# Altium schematic sheet Power.SchDoc
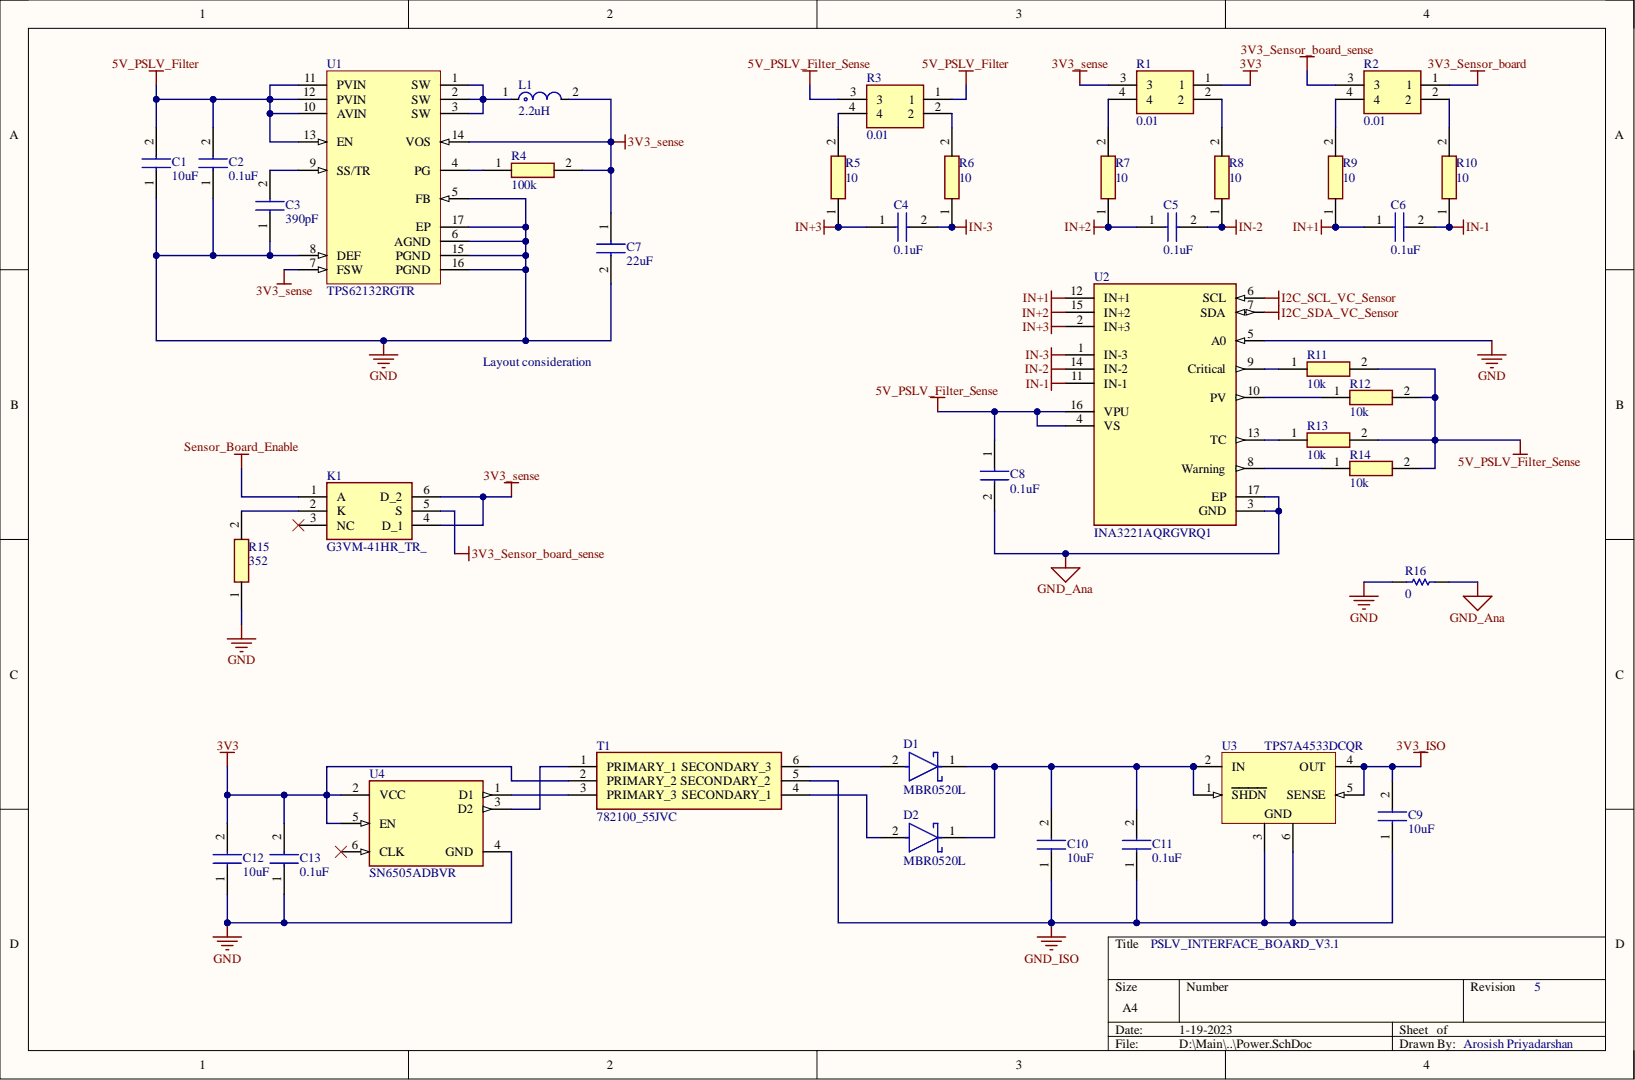
[diagram p0: Power.SchDoc]

## Parts
C1  10uF  [C1206]
C2  0.1uF  [C1206_FT]
C3  390pF  [C1206]
C4  0.1uF  [C1206_FT]
C5  0.1uF  [C1206_FT]
C6  0.1uF  [C1206_FT]
C7  22uF  [C1206]
C8  0.1uF  [C1206_FT]
C9  10uF  [C1206]
C10  10uF  [C1206]
C11  0.1uF  [C1206_FT]
C12  10uF  [C1206]
C13  0.1uF  [C1206_FT]
D1  MBR0520L  [FP-MA02A-MFG]
D2  MBR0520L  [FP-MA02A-MFG]
K1  G3VM-41HR_TR_  [G3VM41HRTR]
L1  2.2uH  [FP-XGL4030-MFG]
R1  0.01  [LVK12R010CER]
R2  0.01  [LVK12R010CER]
R3  0.01  [LVK12R010CER]
R4  100k  [RESC3216X70N]
R5  10  [RESC3116X65N]
R6  10  [RESC3116X65N]
R7  10  [RESC3116X65N]
R8  10  [RESC3116X65N]
R9  10  [RESC3116X65N]
R10  10  [RESC3116X65N]
R11  10k  [RESC3216X70N]
R12  10k  [RESC3216X70N]
R13  10k  [RESC3216X70N]
R14  10k  [RESC3216X70N]
R15  352  [RESC3216X70N]
R16  0  [RESC3216X70X50LL05T20]
T1  782100_55JVC  [78210055JVC]
U1  TPS62132RGTR  [RGT0016C_V]
U2  INA3221AQRGVRQ1  [FP-RGV0016A-IPC_B]
U3  TPS7A4533DCQR  [DCQ0006A_M]
U4  SN6505ADBVR  [FP-DBV0006A-IPC_A]

## Nets
3V3: C12.2 C13.2 R1.1 T1.2(PRIMARY_2) U4.2(VCC) U4.5(EN)
3V3_ISO: C9.2 U3.4(OUT) U3.5(SENSE)
3V3_sense: C7.1 K1.4(D_1) K1.6(D_2) L1.2 R1.3 R4.2 U1.7(FSW) U1.14(VOS)
3V3_Sensor_board: R2.1
3V3_Sensor_board_sense: K1.5(S) R2.3
5V_PSLV_Filter: C1.2 C2.2 R3.1 U1.10(AVIN) U1.11(PVIN) U1.12(PVIN) U1.13(EN)
5V_PSLV_Filter_Sense: C8.1 R3.3 R11.2 R12.2 R13.2 R14.2 U2.4(VS) U2.16(VPU)
GND: C1.1 C2.1 C3.1 C7.2 C12.1 C13.1 R15.1 R16.1 U1.5(FB) U1.6(AGND) U1.8(DEF) U1.15(PGND) U1.16(PGND) U1.17(EP) U2.5(A0) U4.4(GND)
GND_Ana: C8.2 R16.2 U2.3(GND) U2.17(EP)
GND_ISO: C9.1 C10.1 C11.1 T1.5(SECONDARY_2) U3.3 U3.6
I2C_SCL_VC_Sensor: U2.6(SCL)
I2C_SDA_VC_Sensor: U2.7(SDA)
IN+1: C6.1 R9.1 U2.12(IN+1)
IN+2: C5.1 R7.1 U2.15(IN+2)
IN+3: C4.1 R5.1 U2.2(IN+3)
IN-1: C6.2 R10.1 U2.11(IN-1)
IN-2: C5.2 R8.1 U2.14(IN-2)
IN-3: C4.2 R6.1 U2.1(IN-3)
Sensor_Board_Enable: K1.1(A)
NetC3_2: C3.2 U1.9(SS/TR)
NetC10_2: C10.2 C11.2 D1.1 D2.1 U3.1(SHDN) U3.2(IN)
NetD1_2: D1.2 T1.6(SECONDARY_3)
NetD2_2: D2.2 T1.4(SECONDARY_1)
NetK1_2: K1.2(K) R15.2
NetL1_1: L1.1 U1.1(SW) U1.2(SW) U1.3(SW)
NetR1_2: R1.2 R8.2
NetR1_4: R1.4 R7.2
NetR2_2: R2.2 R10.2
NetR2_4: R2.4 R9.2
NetR3_2: R3.2 R6.2
NetR3_4: R3.4 R5.2
NetR4_1: R4.1 U1.4(PG)
NetR11_1: R11.1 U2.9(Critical)
NetR12_1: R12.1 U2.10(PV)
NetR13_1: R13.1 U2.13(TC)
NetR14_1: R14.1 U2.8(Warning)
NetT1_1: T1.1(PRIMARY_1) U4.3(D2)
NetT1_3: T1.3(PRIMARY_3) U4.1(D1)

Not connected: K1 3(NC); U4 6(CLK)

## Library data of the parts
C1 C9 C10 C12 = C1206C106Z4VACTU: Capacitor; Manufacturer_Name: KEMET; Arrow Part Number: C1206C106Z4VACTU; Arrow Price/Stock: https://www.arrow.com/en/products/c1206c106z4vactu/kemet-corporation?region=nac; Component Kind: Standard; Datasheet Link: https://content.kemet.com/datasheets/KEM_C1005_Y5V_SMD.pdf; Library Name: Schlib1.SchLib; Manufacturer_Part_Number: C1206C106Z4VACTU; Mouser Part Number: 80-C1206C106Z4VACTU; Mouser Price/Stock: https://www.mouser.co.uk/ProductDetail/KEMET/C1206C106Z4VACTU?qs=dm5ynNmggfJrhnd05a604w%3D%3D
C2 C4-C6 C8 C11 C13 = C1206X104K5RAC3316: Capacitor; Manufacturer_Name: KEMET; Arrow Part Number: C1206X104K5RAC3316; Arrow Price/Stock: https://www.arrow.com/en/products/c1206x104k5rac3316/kemet-corporation; Component Kind: Standard; Datasheet Link: https://content.kemet.com/datasheets/KEM_X7R_FT_VW_AUDI.pdf; Library Name: Schlib1.SchLib; Manufacturer_Part_Number: C1206X104K5RAC3316; Mouser Part Number: 80-C1206X104K5RC3316; Mouser Price/Stock: https://www.mouser.co.uk/ProductDetail/KEMET/C1206X104K5RAC3316?qs=PzGy0jfpSMtwBv5U2rNx3w%3D%3D
C3 = C1206C391JCGACTU: Capacitor; Manufacturer_Name: KEMET; Component Kind: Standard; Datasheet Link: https://content.kemet.com/datasheets/KEM_C1009_C0G_HV_SMD.pdf; Library Name: Schlib1.SchLib; Manufacturer_Part_Number: C1206C391JCGACTU; Mouser Part Number: 80-C1206C391JCG; Mouser Price/Stock: https://www.mouser.co.uk/ProductDetail/KEMET/C1206C391JCGACTU?qs=Ex32QJu5HxMzYMtFZMlJig%3D%3D
C7 = C1206C226K8RAC7210: Capacitor; Manufacturer_Name: KEMET; Component Kind: Standard; Datasheet Link: https://content.kemet.com/datasheets/KEM_C1002_X7R_SMD.pdf; Library Name: Schlib1.SchLib; Manufacturer_Part_Number: C1206C226K8RAC7210; Mouser Part Number: 80-C1206C226K8R7210; Mouser Price/Stock: https://www.mouser.co.uk/ProductDetail/KEMET/C1206C226K8RAC7210?qs=rrS6PyfT74d338OvQfUspw%3D%3D
D1 D2 = CMP-2000-04926-2: DIODE SCHOTTKY 20V 500MA SOD123; Capacitance: 4pF; Current Rating: 500A; Element Configuration: Single; Forward Voltage: 385mV; Max Forward Surge Current (Ifsm): 5.5A; Max Reverse Leakage Current: 250uA; Polarity: Standard; Power Dissipation: 400mW; Average Rectified Current: 500mA; Component Kind: Standard; Forward Current: 500mA; Height: 1.03mm; Lead Free: Lead Free; Length: 2.7mm; Library Name: Altium Content Vault; Max Operating Temperature: 125°C; Max Repetitive Reverse Voltage (Vrrm): 20V; Max Reverse Voltage (DC): 20V; Max Surge Current: 5.5A; Min Operating Temperature: -65°C; Mount: Surface Mount; Number of Pins: 2; Output Current: 500mA; Package Quantity: 3000; Packaging: Tape and Reel; Peak Non-Repetitive Surge Current: 5.5A; Peak Reverse Current: 250uA; Radiation Hardening: No; REACH SVHC: No SVHC; Voltage Rating (DC): 20V; Weight: 0.03g; Width: 1.6mm
K1 = G3VM-41HR_TR_: Relay or Contactor; Manufacturer_Name: Omron Electronics; Manufacturer_Part_Number: G3VM-41HR(TR); Arrow Part Number: G3VM-41HR(TR); Arrow Price/Stock: http://www.arrow.com/en/products/g3vm-41hr-tr/omron; Component Kind: Standard; Datasheet Link: http://www.mouser.com/datasheet/2/307/en_g3vm_41hr-10625.pdf; Height: 2.1mm; Mouser Part Number: 653-G3VM-41HRTR; Mouser Price/Stock: https://www.mouser.co.uk/ProductDetail/Omron-Electronics/G3VM-41HRTR?qs=FfQ8ThoHJhH033qdX8DYnA%3D%3D
L1 = CMP-11268-000073-1: Inductor Power Shielded Wirewound 2.2uH 20% 1MHz Composite 8.7A 15mOhm DCR Automotive T/R; Component Kind: Standard; Library Name: Altium Content Vault
R1-R3 = LVK12R010CER: Resistor; Manufacturer_Name: Ohmite; Component Kind: Standard; Datasheet Link: https://datasheet.datasheetarchive.com/originals/distributors/Datasheets_SAMA/2077b5e0bf5fd022871e7f732bf17f75.pdf; Height: 0.65mm; Library Name: Schlib1.SchLib; Manufacturer_Part_Number: LVK12R010CER; Mouser Part Number: 588-LVK12R010CER; Mouser Price/Stock: https://www.mouser.co.uk/ProductDetail/Ohmite/LVK12R010CER?qs=Cb2nCFKsA8qfJ9bVKb1vFw%3D%3D
R4 = RN73H2BTTD1003B10: Resistor; Manufacturer_Name: KOA Speer; Component Kind: Standard; Datasheet Link: https://www.mouser.com/datasheet/2/219/RN73H-1843478.pdf; Height: 0.7mm; Library Name: Schlib1.SchLib; Manufacturer_Part_Number: RN73H2BTTD1003B10; Mouser Part Number: 660-RN73H2BTD1003B10; Mouser Price/Stock: https://www.mouser.co.uk/ProductDetail/KOA-Speer/RN73H2BTTD1003B10?qs=LcEtihlZWqYVlem5AUAudQ%3D%3D
R5-R10 = RT1206BRD0710RL: Resistor; Manufacturer_Name: KEMET; Arrow Part Number: RT1206BRD0710RL; Arrow Price/Stock: https://www.arrow.com/en/products/rt1206brd0710rl/yageo; Component Kind: Standard; Datasheet Link: https://www.arrow.com/en/products/rt1206brd0710rl/yageo; Height: 0.65mm; Library Name: Schlib1.SchLib; Manufacturer_Part_Number: RT1206BRD0710RL; Mouser Part Number: 603-RT1206BRD0710RL; Mouser Price/Stock: https://www.mouser.co.uk/ProductDetail/Yageo/RT1206BRD0710RL/?qs=D0UFUVWohuYNXkcSF2ehpw%3D%3D
R11-R14 = RN73H2BTTD1002B10: Resistor; Manufacturer_Name: KOA Speer; Arrow Part Number: RN73H2BTTD1002B10; Arrow Price/Stock: https://www.arrow.com/en/products/rn73h2bttd1002b10/koa-speer-electronics; Component Kind: Standard; Datasheet Link: https://th.mouser.com/datasheet/2/219/RN73H-1843478.pdf; Height: 0.7mm; Manufacturer_Part_Number: RN73H2BTTD1002B10; Mouser Part Number: 660-RN73H2BTD1002B10; Mouser Price/Stock: https://www.mouser.co.uk/ProductDetail/KOA-Speer/RN73H2BTTD1002B10?qs=35zkduByVmhPt1hMGurtPw%3D%3D
R15 = RN73H2BTTD3520F100: Resistor; Manufacturer_Name: KOA Speer; Component Kind: Standard; Datasheet Link: https://www.mouser.in/datasheet/2/219/RN73H-1843478.pdf; Height: 0.7mm; Manufacturer_Part_Number: RN73H2BTTD3520F100
R16 = CMP-2003-00541-1: Manufacturer: Panasonic; Part Number: ERJ-8GEY0R00V; Component Kind: Standard; Library Name: Altium Content Vault
T1 = 782100_55JVC: Transformer; Manufacturer_Name: Murata Electronics; Manufacturer_Part_Number: 782100/55JVC; Arrow Part Number: 782100/55JVC; Arrow Price/Stock: https://www.arrow.com/en/products/78210055jvc/murata-power-solutions; Component Kind: Standard; Datasheet Link: https://www.mouser.com/datasheet/2/281/murata_04172018_KMP_782100_A01.D03_Prelim-1321013.pdf; Height: 6.65mm; Mouser Part Number: 580-782100/55JVC; Mouser Price/Stock: https://www.mouser.co.uk/ProductDetail/Murata-Power-Solutions/782100-55JVC?qs=55YtniHzbhB45Up3tSKmQQ%3D%3D
U1 = CMP-0323-00152-3: Buck Step Down Regulator with 3 to 17 V Input and 3.3 V Output, -40 to 85 degC, 16-Pin QFN (RGT), Green (RoHS & no Sb/Br); Manufacturer: Texas Instruments; Duty Cycle(Max)(%): 100; Iout(Max)(A): 3; Iq(Typ)(mA): 0.017; Preset Vout(V): 3.3; Rating: Catalog; Regulated Outputs(#): 1; SubFamily: Step-Down Regulator; Switch Current Limit(Typ)(A): 4.2; Synchronous Rectification: TRUE; Vin(Max)(V): 17; Code_JEDEC: MO-220; Component Kind: Standard; ComponentLink1Description: Datasheet; ComponentLink1URL: http://www.ti.com/general/docs/lit/getliterature.tsp?genericPartNumber=TPS62132&fileType=pdf; ComponentLink2Description: Package Specification; ComponentLink2URL: http://www.ti.com/litv/pdf/mpqf119g; ComponentLink3Description: URL Link1; ComponentLink3URL: Samples URL:http://www.ti.com/product/tps62132#samples; DatasheetVersion: SLVSAG7; Eco-Plan: Green (RoHS & no Sb/Br); Library Name: Altium Content Vault; Mounting Technology: Surface Mount; PackageDescription: 16-Pin Plastic Quad Flat No-Lead, 3 x 3 mm Body, 0.5 mm Pitch, 1.7 x 1.7 mm Exposed Pad; PackageReference: RGT0016C; PackageVersion: revH, Oct-2011; PartNumber: TPS62132RGTR; Topology: Buck; Vin(Min)(V): 3; Vout(Max)(V): 3.3; Vout(Min)(V): 3.3
U2 = CMP-04895-000301-1: IC CURRENT SHUNT MONITOR 16VQFN; Accuracy: 0.25%; Case/Package: VQFN; Component Kind: Standard; Lead Free: Lead Free; Library Name: Altium Content Vault; Max Operating Temperature: 125°C; Min Operating Temperature: -40°C; Mount: Surface Mount; Packaging: Tape and Reel; RoHS Compliant: Yes
U3 = CMP-0395-00360-2: Single Output Fast Transient Response LDO, 1.5 A, Fixed 3.3 V Output, 2.1 to 20 V Input, 6-pin SOT-223 (DCQ), -40 to 125 degC, Green (RoHS & no Sb/Br); Manufacturer: Texas Instruments; Accuracy(%): 1; Fixed Output Options(V): 3.3; Iout(Max)(A): 1.5; SubFamily: Single Channel LDO; Vdo(Typ)(mV): 300; Vin(Max)(V): 20; Vin(Min)(V): 2.1; Component Kind: Standard; ComponentLink1Description: Manufacturer URL; ComponentLink1URL: http://www.ti.com/; ComponentLink2Description: Datasheet; ComponentLink2URL: http://www.ti.com/general/docs/lit/getliterature.tsp?genericPartNumber=TPS7A4533&fileType=pdf; ComponentLink3Description: Package Specification; ComponentLink3URL: http://www.ti.com/litv/pdf/mpds098c; DatasheetVersion: SLVS720D; Eco-Plan: Green (RoHS & no Sb/Br); Iq(Typ)(mA): 1; Library Name: Altium Content Vault; Mounting Technology: Surface Mount; PackageDescription: 6-Pin Plastic Small Outline, Body 3.5 x 6.5 mm, Pitch 1.27 mm; PackageReference: DCQ0006A; PackageVersion: 4202109/D; PartNumber: TPS7A4533DCQR; Vout Adj(Max)(V): 3.3; Vout Adj(Min)(V): 3.3
U4 = CMP-04910-000063-1: IC TRANSFORMER DRIVER 6SOT-23; Operating Supply Current: 1mA; Case/Package: SOT-23-6; Component Kind: Standard; Lead Free: Lead Free; Library Name: Altium Content Vault; Max Operating Temperature: 125°C; Min Operating Temperature: -55°C; Mount: Surface Mount; Packaging: Tape and Reel; RoHS Compliant: Yes
